# Altium schematic sheet [6] SENSORS.SchDoc("[6] SENSORS")
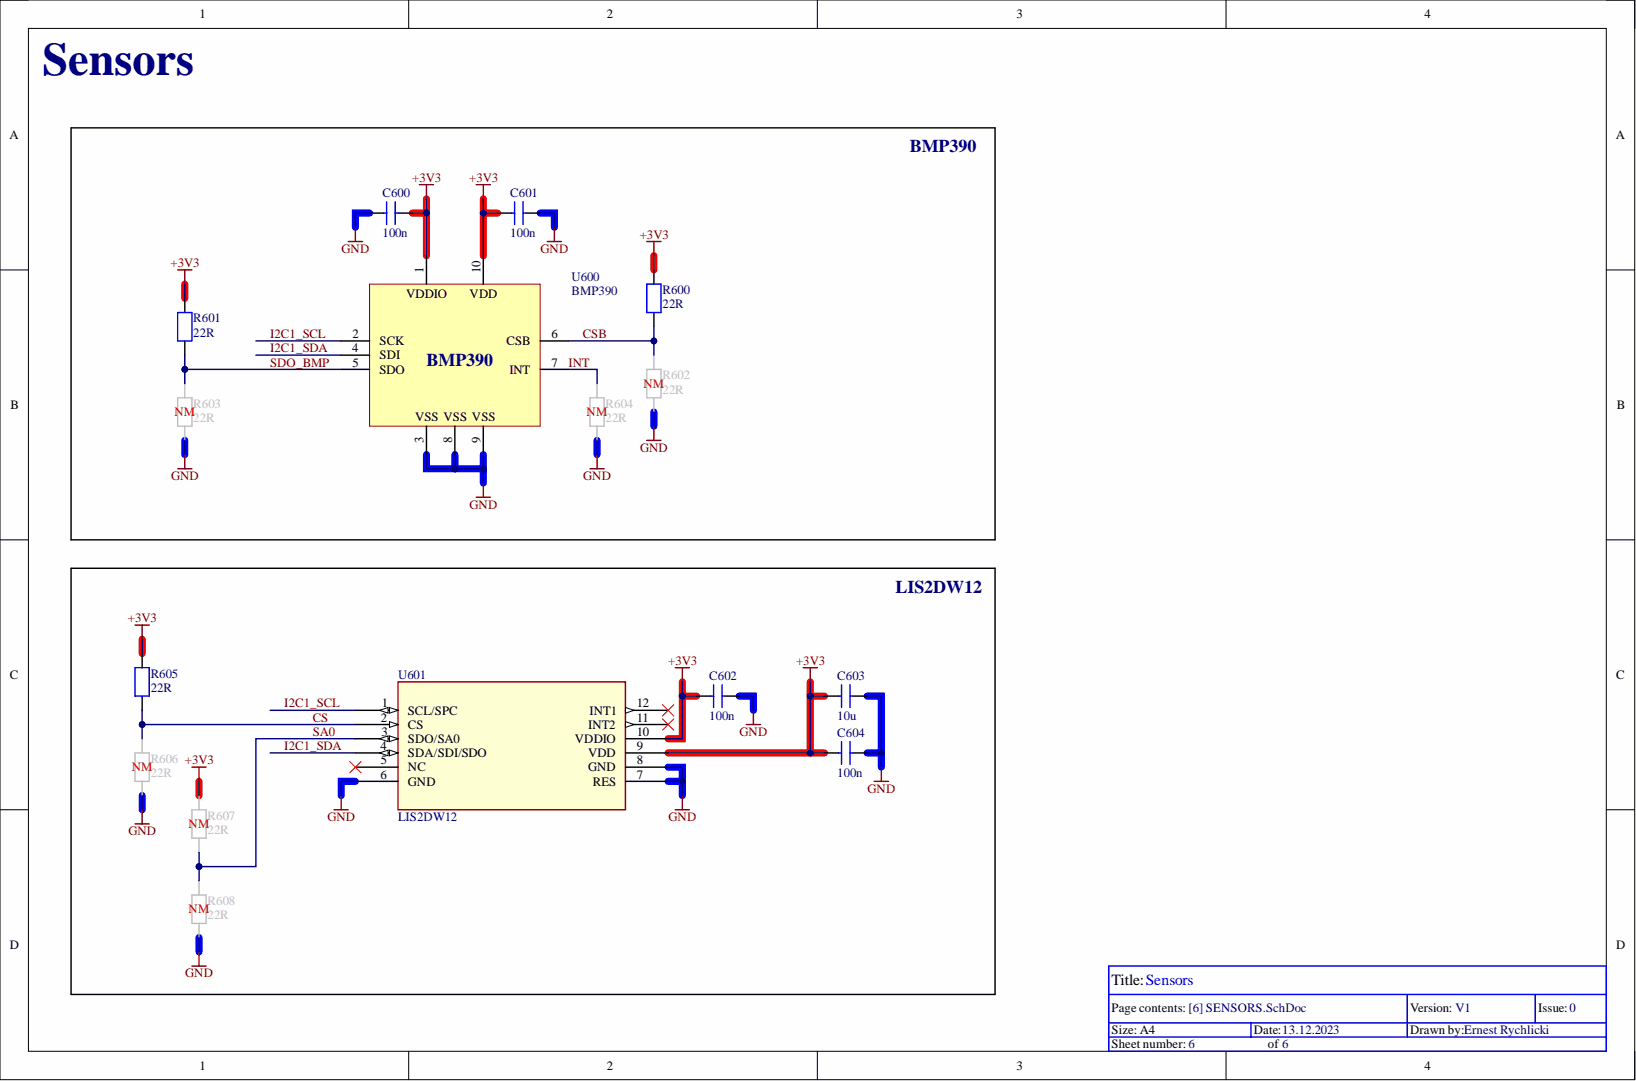
[diagram p0: [6] SENSORS.SchDoc]

## Parts
C600  100n  [C0402]
C601  100n  [C0402]
C602  100n  [C0402]
C603  10u  [C0402]
C604  100n  [C0402]
R600  22R  [R0603]
R601  22R  [R0603]
R602  22R  [R0603]
R603  22R  [R0603]
R604  22R  [R0603]
R605  22R  [R0603]
R606  22R  [R0603]
R607  22R  [R0603]
R608  22R  [R0603]
U600  BMP390  [LGA10]
U601  LIS2DW12  [LGA12]

## Nets
+3V3: C600.1 C601.2 C602.2 C603.2 C604.2 R600.2 R601.2 R605.2 R607.2 U600.1 U600.10 U601.9(VDD) U601.10(VDDIO)
GND: C600.2 C601.1 C602.1 C603.1 C604.1 R602.1 R603.1 R604.1 R606.1 R608.1 U600.3 U600.8 U600.9 U601.6(GND) U601.7(RES) U601.8(GND)
CS: R605.1 R606.2 U601.2(CS)
CSB: R600.1 R602.2 U600.6(CSB)
I2C1_SCL: U600.2(SCK) U601.1(SCL/SPC)
I2C1_SDA: U600.4(SDI) U601.4(SDA/SDI/SDO)
INT: R604.2 U600.7(INT)
SA0: R607.1 R608.2 U601.3(SDO/SA0)
SDO_BMP: R601.1 R603.2 U600.5(SDO)

Not connected: U601 5(NC) 11(INT2) 12(INT1)

## Library data of the parts
C600-C602 C604 = 04023C104KAT2A: Multilayer Ceramic Capacitor, 0.1 uF, 25 V, ± 10%, X7R, 0402 [1005 Metric]; Manufacturer: Kyocera AVX; Material: 4241ConductorMaterial; Value: 100nF; Voltage: 25V; Case Code (Imperial): 0402; Case Code (Metric): 1005; Case/Package: 0402; Category: Ceramic Capacitors; Component Kind: Standard; ComponentLink1Description: https://datasheet.ciiva.com/6265/cx7r-6265272.pdf?src-supplier=Digi-Key; ComponentLink1URL: https://datasheet.ciiva.com/6265/cx7r-6265272.pdf?src-supplier=Digi-Key; ComponentLink2Description: https://datasheet.ciiva.com/1123/1679506-1123344.pdf?src-supplier=Element14; ComponentLink2URL: https://datasheet.ciiva.com/1123/1679506-1123344.pdf?src-supplier=Element14; ComponentLink3Description: https://datasheet.ciiva.com/7709/1679506-7709527.pdf?src-supplier=Element14; ComponentLink3URL: https://datasheet.ciiva.com/7709/1679506-7709527.pdf?src-supplier=Element14; ComponentLink4Description: https://datasheet.ciiva.com/14906/cx7r-14906223.pdf?src-supplier=Digi-Key; ComponentLink4URL: https://datasheet.ciiva.com/14906/cx7r-14906223.pdf?src-supplier=Digi-Key; ComponentLink5Description: https://datasheet.ciiva.com/7614/cx7r-7614663.pdf?src-supplier=Digi-Key; ComponentLink5URL: https://datasheet.ciiva.com/7614/cx7r-7614663.pdf?src-supplier=Digi-Key; Dielectric: X7R; Lead Free: Lead Free; Library Name: ER_SCH_LIB.SchLib; Manufacturer Part Number: 04023C104KAT2A; Max Operating Temperature: 125°C; Min Operating Temperature: -55°C; Mounting Technology: Surface Mount; Packaging: Cut Tape; Pins: 2; Pricing: 10000=0,0064, 20000=0,0061, 30000=0,0058, 50000=0,0052, 100000=0,0042, 150000=0,0038 (USD); Reach SVHC Compliant: No; RoHS: Yes; RoHS Compliant: Yes; Series: -; Stock: 5700000; Supplier: Arrow Electronics; Supplier Part Number: 04023C104KAT2A; Tolerance: 10%; Voltage Rating: 25V; Voltage Rating (DC): 25V; Width: 1mm
C603 = GRJ155R60J106ME11D: Capacitor Ceramic Mlcc 0402 10UF 6.3V SMD; Manufacturer: Murata; Tolerance: 20%; Case Code (Imperial): 0402; Case Code (Metric): 1005; Case/Package: 0402; Category: Ceramic Capacitors; Component Kind: Standard; ComponentLink1Description: https://datasheet.octopart.com/GRJ155R60J106ME11D-Murata-datasheet-149522788.pdf?src-supplier=Newark; ComponentLink1URL: https://datasheet.octopart.com/GRJ155R60J106ME11D-Murata-datasheet-149522788.pdf?src-supplier=Newark; ComponentLink2Description: http://psearch.en.murata.com/capacitor/product/GRJ155R60J106ME11%23.pdf?src-supplier=Digi-Key; ComponentLink2URL: http://psearch.en.murata.com/capacitor/product/GRJ155R60J106ME11%23.pdf?src-supplier=Digi-Key; ComponentLink3Description: https://datasheet.octopart.com/GRJ155R60J106ME11D-Murata-datasheet-165579666.pdf?src-supplier=TME; ComponentLink3URL: https://datasheet.octopart.com/GRJ155R60J106ME11D-Murata-datasheet-165579666.pdf?src-supplier=TME; Features: Soft Termination; Length: 0.039inch; Library Name: ER_SCH_LIB.SchLib; Manufacturer Part Number: GRJ155R60J106ME11D; Max Operating Temperature: 85°C; Min Operating Temperature: -55°C; Mounting Technology: Surface Mount; Packaging: Cut Tape; Pricing: 10000=0,0311, 20000=0,0295, 30000=0,0278, 50000=0,0262, 100000=0,0221, 250000=0,0204 (USD); RoHS: Yes; RoHS Compliant: Yes; Series: GRJ; Stock: 10000; Supplier: Arrow Electronics; Supplier Part Number: GRJ155R60J106ME11D; Thickness: 0.028inch; Value: 10uF; Voltage Rating: 6.3V; Width: 0.02inch
R600-R608 = RC0603JR-0722RL: SMD Chip Resistor, 22 Ohm, ± 5%, 100 mW, 0603 [1608 Metric], Thick Film, General Purpose; Manufacturer: Yageo; Voltage Rating (AC): 50V; Category: Chip SMD Resistors; Component Kind: Standard; ComponentLink1Description: https://datasheet.ciiva.com/7746/443971-7746471.pdf?src-supplier=Element14; ComponentLink1URL: https://datasheet.ciiva.com/7746/443971-7746471.pdf?src-supplier=Element14; ComponentLink2Description: https://datasheet.ciiva.com/7746/443971-7746472.pdf?src-supplier=Element14; ComponentLink2URL: https://datasheet.ciiva.com/7746/443971-7746472.pdf?src-supplier=Element14; ComponentLink3Description: https://datasheet.ciiva.com/46394/443971-46394999.pdf?src-supplier=Element14; ComponentLink3URL: https://datasheet.ciiva.com/46394/443971-46394999.pdf?src-supplier=Element14; ComponentLink4Description: https://datasheet.ciiva.com/15189/getdatasheetpartid-493085-15189862.pdf?src-supplier=Verical; ComponentLink4URL: https://datasheet.ciiva.com/15189/getdatasheetpartid-493085-15189862.pdf?src-supplier=Verical; Library Name: ER_SCH_LIB.SchLib; Manufacturer Part Number: RC0603JR-0722RL; Pricing: 1=0,0925, 10=0,0259, 25=0,0135, 100=0,005, 250=0,0047, 500=0,0043, 1000=0,0042, 3000=0,0026 (USD); RoHS: Yes; Stock: 4035; Supplier: Arrow Electronics; Supplier Part Number: RC0603JR-0722RL
U600 = BMP390: Pressure sensor, 300-1250hPa, 2.0*2.0*0.75mm, I2C/SPI; Manufacturer: Bosch Sensortec; Category: Uncategorized; Component Kind: Standard; Library Name: ER_SCH_LIB.SchLib; Manufacturer Part Number: BMP390; RoHS: No; Supplier: Future Electronics; Supplier Part Number: 3151950
U601 = LIS2DW12TR: LIS2DW12 Series 3 Axis ±2/±4/±8/±16g 3-Axis "Femto" Accelerometer - LGA-12; Manufacturer: STMicroelectronics; Axis: X, Y, Z; Bandwidth: 400Hz; Output Type: SPI,I2C; Case/Package: LGA; Component Kind: Standard; ComponentLink1Description: http://www.farnell.com/datasheets/2581666.pdf?src-supplier=Farnell; ComponentLink1URL: http://www.farnell.com/datasheets/2581666.pdf?src-supplier=Farnell; ComponentLink2Description: http://www.st.com/content/ccc/resource/technical/document/datasheet/group3/30/3a/4e/6b/68/16/4a/35/DM00323179/files/DM00323179.pdf/jcr:content/translations/en.DM00323179.pdf?src-supplier=Digi-Key; ComponentLink2URL: http://www.st.com/content/ccc/resource/technical/document/datasheet/group3/30/3a/4e/6b/68/16/4a/35/DM00323179/files/DM00323179.pdf/jcr:content/translations/en.DM00323179.pdf?src-supplier=Digi-Key; ComponentLink3Description: http://www.farnell.com/datasheets/2581666.pdf?src-supplier=Newark; ComponentLink3URL: http://www.farnell.com/datasheets/2581666.pdf?src-supplier=Newark; Library Name: ER_SCH_LIB.SchLib; Manufacturer Part Number: LIS2DW12TR; Max Operating Temperature: 85°C; Min Operating Temperature: -40°C; Mount: Surface Mount; Number of Pins: 12; Packaging: Cut Tape; RoHS Compliant: Yes; Series: -; Stock 1: 16238; Supplier 1: Farnell; Supplier Part Number 1: 2849615
